# Altium schematic sheet Motor_drive.SchDoc
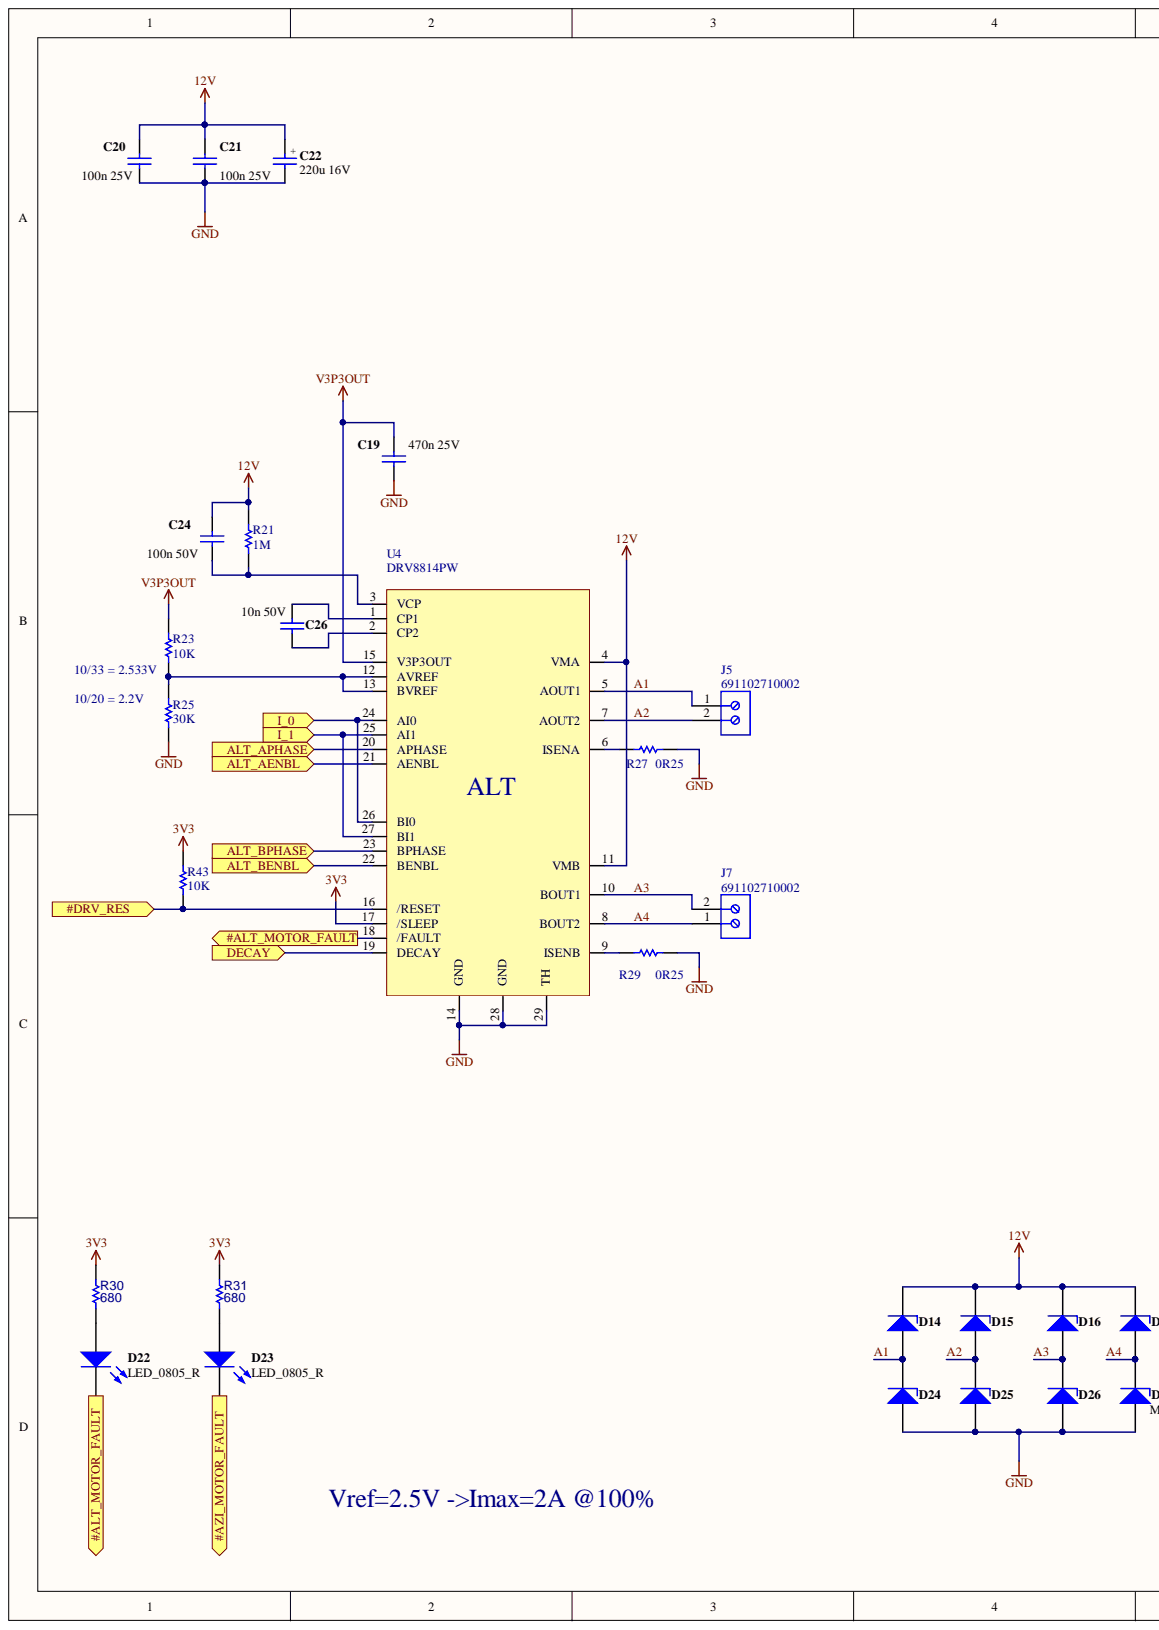
[diagram p0: Motor_drive.SchDoc, left]
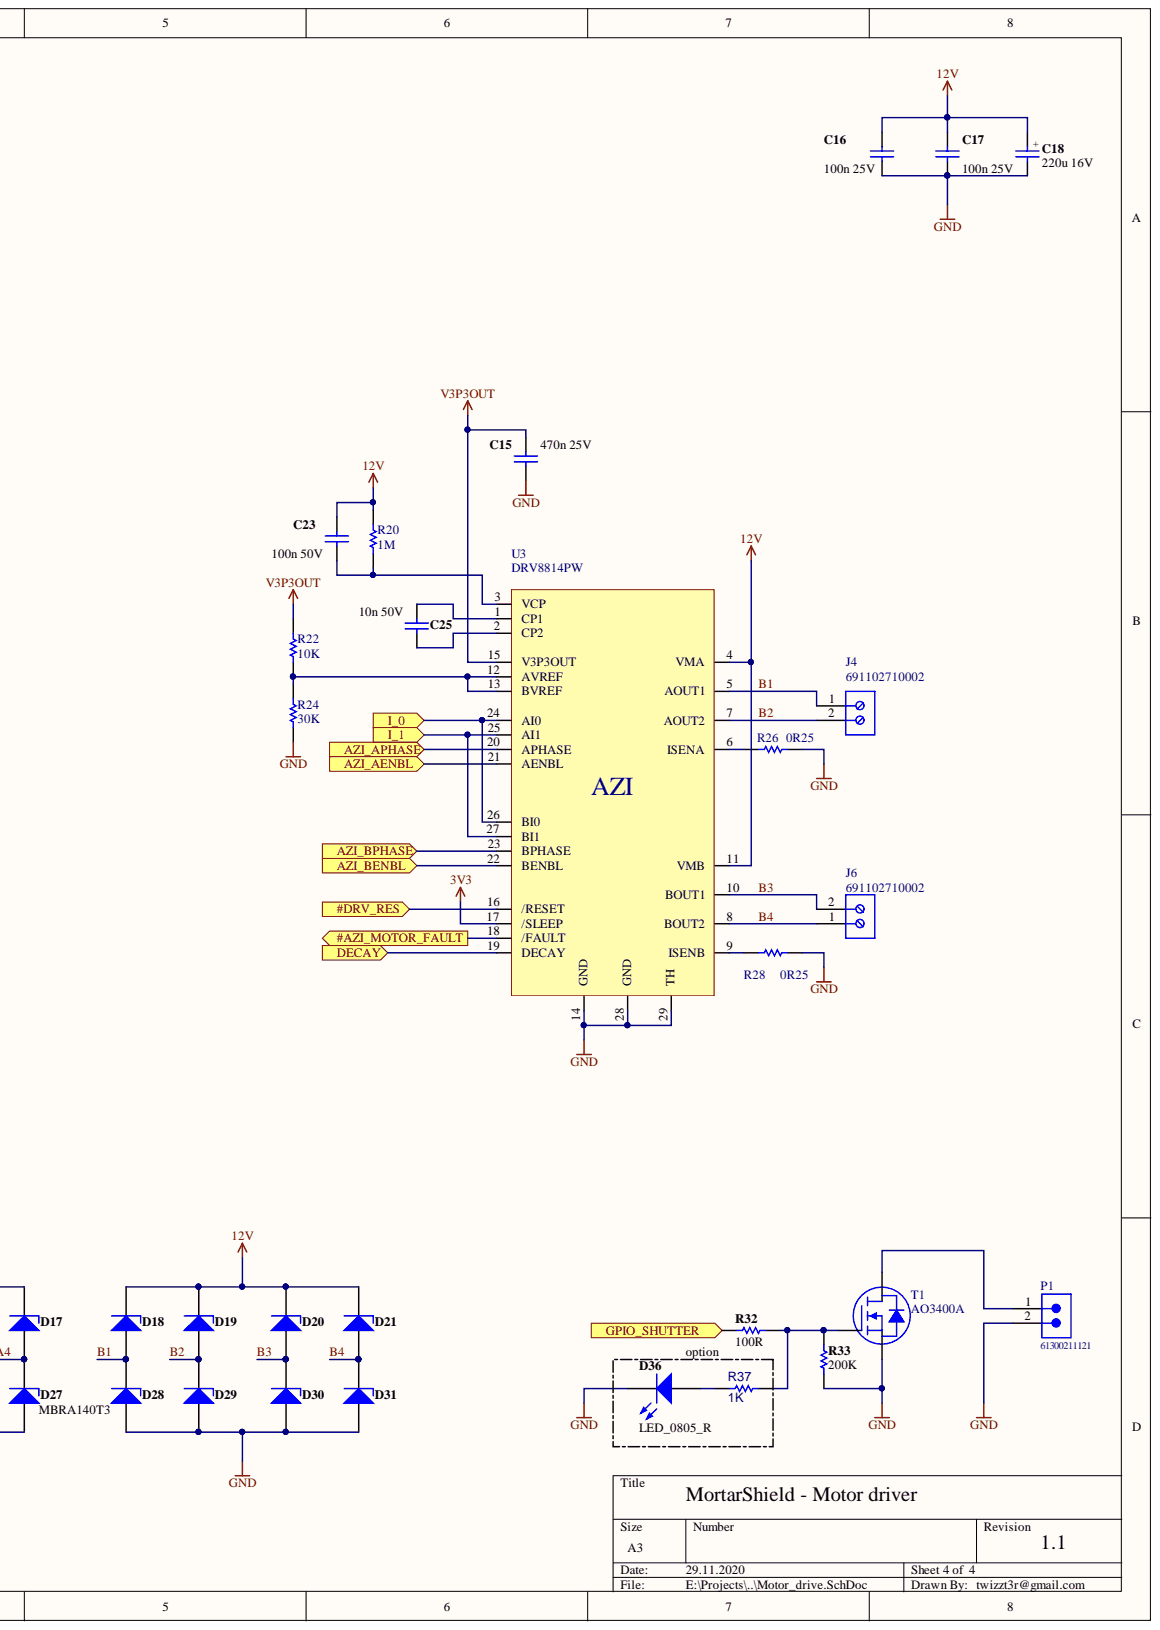
[diagram p1: Motor_drive.SchDoc, right]

## Parts
C15  value 470n 25V  [C_0603]  (hidden comment: C_100n_25V_0603)
C16  value 100n 25V  [C_0603]  (hidden comment: C_100n_25V_0603)
C17  value 100n 25V  [C_0603]  (hidden comment: C_100n_25V_0603)
C18  value 220u 16V  [WCAP-ASLL_6.3x7.7]  (hidden comment: C_220u_16V_radial)
C19  value 470n 25V  [C_0603]  (hidden comment: C_100n_25V_0603)
C20  value 100n 25V  [C_0603]  (hidden comment: C_100n_25V_0603)
C21  value 100n 25V  [C_0603]  (hidden comment: C_100n_25V_0603)
C22  value 220u 16V  [WCAP-ASLL_6.3x7.7]  (hidden comment: C_220u_16V_radial)
C23  value 100n 50V  [C_0603]  (hidden comment: C_100n_25V_0603)
C24  value 100n 50V  [C_0603]  (hidden comment: C_100n_25V_0603)
C25  value 10n 50V  [C_0603]  (hidden comment: C_10n_50v)
C26  value 10n 50V  [C_0603]  (hidden comment: C_10n_50v)
D14  MBRA140T3  [D_SMA]
D15  MBRA140T3  [D_SMA]
D16  MBRA140T3  [D_SMA]
D17  MBRA140T3  [D_SMA]
D18  MBRA140T3  [D_SMA]
D19  MBRA140T3  [D_SMA]
D20  MBRA140T3  [D_SMA]
D21  MBRA140T3  [D_SMA]
D22  LED_0805_R  [D_0805]
D23  LED_0805_R  [D_0805]
D24  MBRA140T3  [D_SMA]
D25  MBRA140T3  [D_SMA]
D26  MBRA140T3  [D_SMA]
D27  MBRA140T3  [D_SMA]
D28  MBRA140T3  [D_SMA]
D29  MBRA140T3  [D_SMA]
D30  MBRA140T3  [D_SMA]
D31  MBRA140T3  [D_SMA]
D36  LED_0805_R  [D_0805]
J4  691102710002  [691102710002]
J5  691102710002  [691102710002]
J6  691102710002  [691102710002]
J7  691102710002  [691102710002]
P1  61300211121  [61300211121]
R20  1M  [R_0603]
R21  1M  [R_0603]
R22  10K  [R_0603]
R23  10K  [R_0603]
R24  30K  [R_0603]
R25  30K  [R_0603]
R26  0R25  [R_2512]
R27  0R25  [R_2512]
R28  0R25  [R_2512]
R29  0R25  [R_2512]
R30  R680R  [R_0603]  (value not drawn)
R31  R680R  [R_0603]  (value not drawn)
R32  value 100R  [R_0603]  (hidden comment: R_0402)
R33  value 200K  [R_0402]  (hidden comment: R_0402)
R37  VALUE_TXT: 1K  [R_0603]  (hidden comment: R_1K_0603)
R43  10K  [R_0603]
T1  mfg_pn: AO3400A  [SOT23]  (hidden comment: MOSFET N-CH SOT-23-3)
U3  DRV8814PW  [SOP127P600-8N-DRV]
U4  DRV8814PW  [SOP127P600-8N-DRV]

## Ports
#ALT_MOTOR_FAULT, #AZI_MOTOR_FAULT, #DRV_RES, ALT_AENBL, ALT_APHASE, ALT_BENBL, ALT_BPHASE, AZI_AENBL, AZI_APHASE, AZI_BENBL, AZI_BPHASE, DECAY, GPIO_SHUTTER, I_0, I_1

## Nets
3V3: R30.2 R31.2 R43.2 U3.17(/SLEEP) U4.17(/SLEEP)
12V: C16.2 C17.2 C18.2 C20.2 C21.2 C22.2 C23.1 C24.1 D14.2 D15.2 D16.2 D17.2 D18.2 D19.2 D20.2 D21.2 R20.2 R21.2 U3.4(VMA) U3.11(VMB) U4.4(VMA) U4.11(VMB)
GND: C15.1 C16.1 C17.1 C18.1 C19.1 C20.1 C21.1 C22.1 D24.1 D25.1 D26.1 D27.1 D28.1 D29.1 D30.1 D31.1 D36.2 P1.2 R24.1 R25.1 R26.1 R27.1 R28.1 R29.1 R33.2 T1.2 U3.14(GND) U3.28(GND) U3.29(TH) U4.14(GND) U4.28(GND) U4.29(TH)
V3P3OUT: C15.2 C19.2 R22.2 R23.2 U3.15(V3P3OUT) U4.15(V3P3OUT)
#ALT_MOTOR_FAULT: D22.2 U4.18(/FAULT) ; port #ALT_MOTOR_FAULT
#AZI_MOTOR_FAULT: D23.2 U3.18(/FAULT) ; port #AZI_MOTOR_FAULT
#DRV_RES: R43.1 U3.16(/RESET) U4.16(/RESET) ; port #DRV_RES
A1: D14.1 D24.2 J5.1 U4.5(AOUT1)
A2: D15.1 D25.2 J5.2 U4.7(AOUT2)
A3: D16.1 D26.2 J7.2 U4.10(BOUT1)
A4: D17.1 D27.2 J7.1 U4.8(BOUT2)
ALT_AENBL: U4.21(AENBL) ; port ALT_AENBL
ALT_APHASE: U4.20(APHASE) ; port ALT_APHASE
ALT_BENBL: U4.22(BENBL) ; port ALT_BENBL
ALT_BPHASE: U4.23(BPHASE) ; port ALT_BPHASE
AZI_AENBL: U3.21(AENBL) ; port AZI_AENBL
AZI_APHASE: U3.20(APHASE) ; port AZI_APHASE
AZI_BENBL: U3.22(BENBL) ; port AZI_BENBL
AZI_BPHASE: U3.23(BPHASE) ; port AZI_BPHASE
B1: D18.1 D28.2 J4.1 U3.5(AOUT1)
B2: D19.1 D29.2 J4.2 U3.7(AOUT2)
B3: D20.1 D30.2 J6.2 U3.10(BOUT1)
B4: D21.1 D31.2 J6.1 U3.8(BOUT2)
DECAY: U3.19(DECAY) U4.19(DECAY) ; port DECAY
GPIO_SHUTTER: R32.1 ; port GPIO_SHUTTER
I_0: U3.24(AI0) U3.26(BI0) U4.24(AI0) U4.26(BI0) ; port I_0
I_1: U3.25(AI1) U3.27(BI1) U4.25(AI1) U4.27(BI1) ; port I_1
NetC23_2: C23.2 R20.1 U3.3(VCP)
NetC24_2: C24.2 R21.1 U4.3(VCP)
NetC25_1: C25.1 U3.2(CP2)
NetC25_2: C25.2 U3.1(CP1)
NetC26_1: C26.1 U4.2(CP2)
NetC26_2: C26.2 U4.1(CP1)
NetD22_1: D22.1 R30.1
NetD23_1: D23.1 R31.1
NetD36_1: D36.1 R37.1
NetP1_1: P1.1 T1.3
NetR22_1: R22.1 R24.2 U3.12(AVREF) U3.13(BVREF)
NetR23_1: R23.1 R25.2 U4.12(AVREF) U4.13(BVREF)
NetR26_2: R26.2 U3.6(ISENA)
NetR27_2: R27.2 U4.6(ISENA)
NetR28_2: R28.2 U3.9(ISENB)
NetR29_2: R29.2 U4.9(ISENB)
NetR32_2: R32.2 R33.1 R37.2 T1.1

## Library data of the parts
C15 C19 = C_100n_25V_0603: 100n 25V 0603 X7R; Component Kind: Standard; Library Name: Kayon_01.SCHLIB
C16 C17 C20 C21 C23 C24 = C_100n_25V_0603: 100n 25V 0603 X7R; mfg_pn: 885012206071; Component Kind: Standard; Library Name: Kayon_01.SCHLIB
  C17 C21: Value: 100n 25V
C18 C22 = C_220u_16V_radial: mfg_pn: 865060345007; Rated Voltage: 16v; Component Kind: Standard; Library Name: SCH.SchLib
C25 C26 = C_10n_50v: C; Component Kind: Standard; Library Name: SCH.SchLib
D14-D21 D24-D31 = D_SHCHOTTKY_MBRA140T3: Diode; Component Kind: Standard; Library Name: SCH.SchLib
D22 D23 D36 = LED_0805: LED; mfg_pn: LL-S170VC-V1-1B; Component Kind: Standard; Library Name: SCH.SchLib
J4-J7 = 691102710002: WR-TBL Serie 102 Horizontal Entry Modular, Pressure Clamp, pitch 5mm, 2p; Compliance: Lead free / RoHS; Modularity: Yes; PCB/Cable/Panel: PCB; Pin Number: 2; Rated Current [UL]: 15A; Working Voltage [UL]: 250VAC; Application: Pressure Clamp; Component Kind: Standard; ComponentLink1Description: Manufacturer; ComponentLink1URL: http://www.we-online.de; ComponentLink2Description: Datasheet; ComponentLink2URL: https://katalog.we-online.de/em/datasheet/691102710002.pdf; Library Name: SCH.SchLib; Mounting Technology: THT; Operating Temperature: -40 to +105degC; Packaging: Box; Part Number: 691102710002; Pitch: 5mm; Published Date: October 2019; Type: Horizontal; Publisher: Wurth Elektronik
P1 = 61300211121: WR-PHD Pin Header, THT, pitch 2.54mm, Single Row, Vertical, 2p; Manufacturer: Wurth Elektronik; Contact Resistance: 20mOhm; Pin Number: 2; Rated Current: 3A; Withstanding Voltage: 500VAC; Working Voltage: 250VAC; Component Kind: Standard; ComponentLink1Description: Manufacturer; ComponentLink1URL: http://www.we-online.de; ComponentLink2Description: Datasheet; ComponentLink2URL: https://www.we-online.com/catalog/datasheet/61300211121.pdf; Gender: Pin Header; Library Name: SCH.SchLib; Manufacturer Part Number: 61300211121; Mounting Technology: THT; Operating Temperature: -40 to +105degC; Orientation: Vertical; Packaging: Bag; Pitch: 2.54mm; Published Date: May 2020; Row Number: Single
R20-R25 R43 = R_0603: Component Kind: Standard; Library Name: SCH.SchLib
R26 R28 R29 = R_1206: Component Kind: Standard; Description: R_1206; Library Name: SCH.SchLib
R27 = R_2512: Component Kind: Standard; Description: R_2512; Library Name: SCH.SchLib
R30 R31 = R680R: SMD RES 680R-1%-1/10W;0603; Manufacturer: YAGEO; MPN: RC0603FR-07680RL; OPL_PART_NO: 301012092; VALUE_TXT: 680; Component Kind: Standard; Library Name: SCH.SchLib; Package: 0603
R32 R33 = R_0402: 0402 (1005 Metric)  SMD; Case-EIA: 0402; Case-Metric: 1005; Power: 0.063 W; Tolerance: 5 %; Component Kind: Standard; Technology: SMT
  R33: Library Name: Kayon_01.SCHLIB
R37 = R_1K_0603: SMD RES 1K-1%-1/10W; Manufacturer: YAGEO; Component Kind: Standard; Library Name: SCH.SchLib; Package: 0603
U3 U4 = DRV8814: Component Kind: Standard; Description: DRV8814PW; Library Name: SCH.SchLib; mfg_pn: DRV8814PW
T1 = MOSFET N-CH SOT-23-3: Component Kind: Standard; Library Name: Kayon_01.SCHLIB
